# Altium schematic sheet MCU.SchDoc
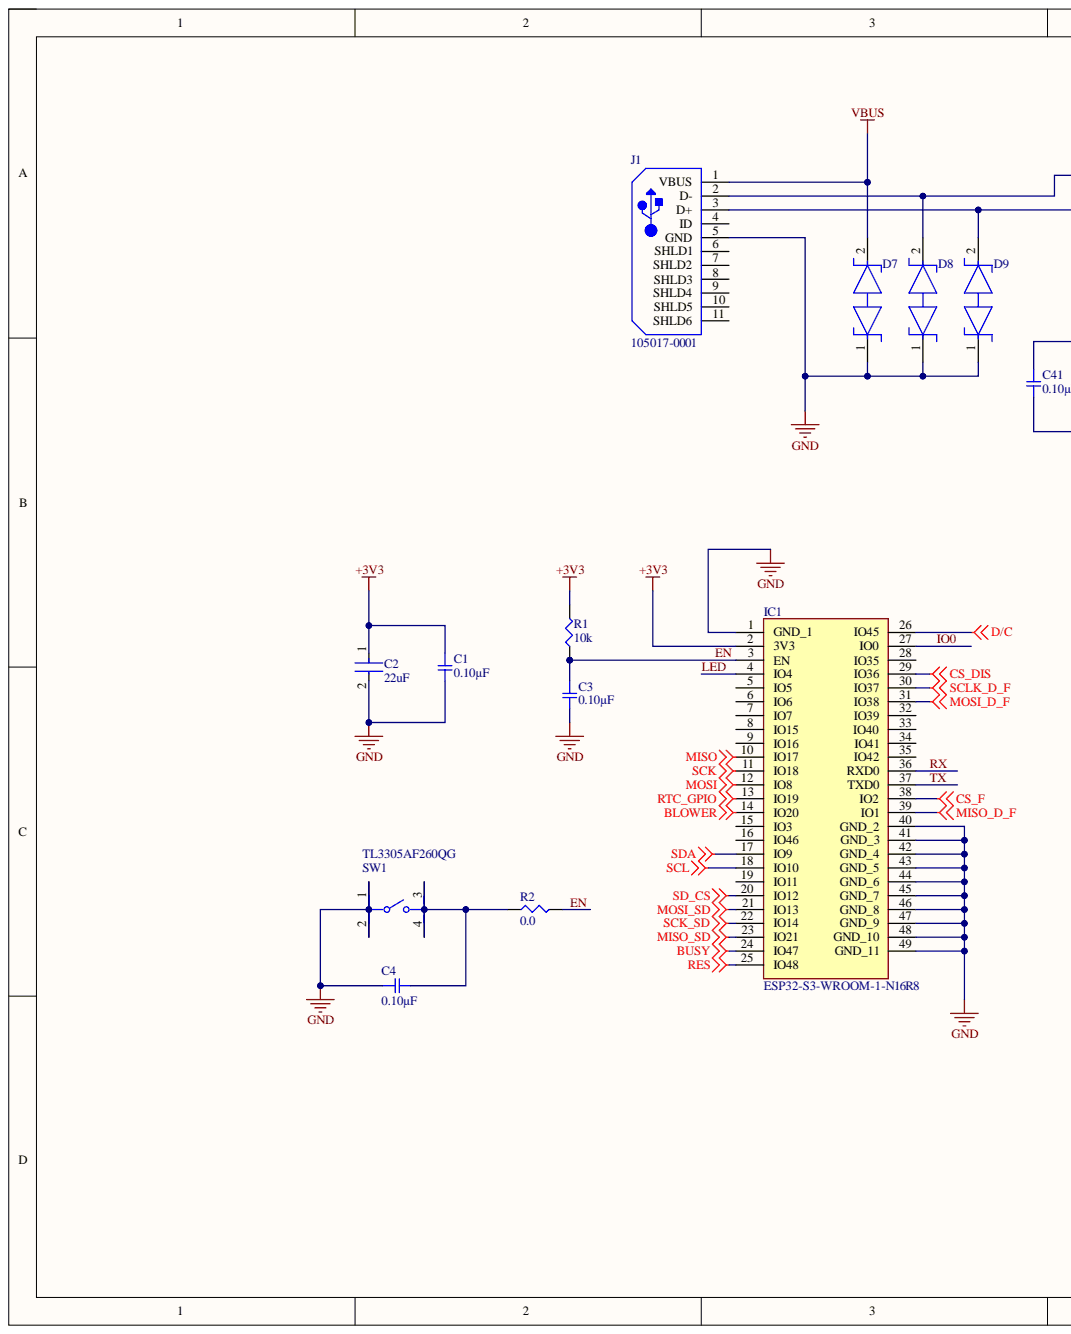
[diagram p0: MCU.SchDoc, left]
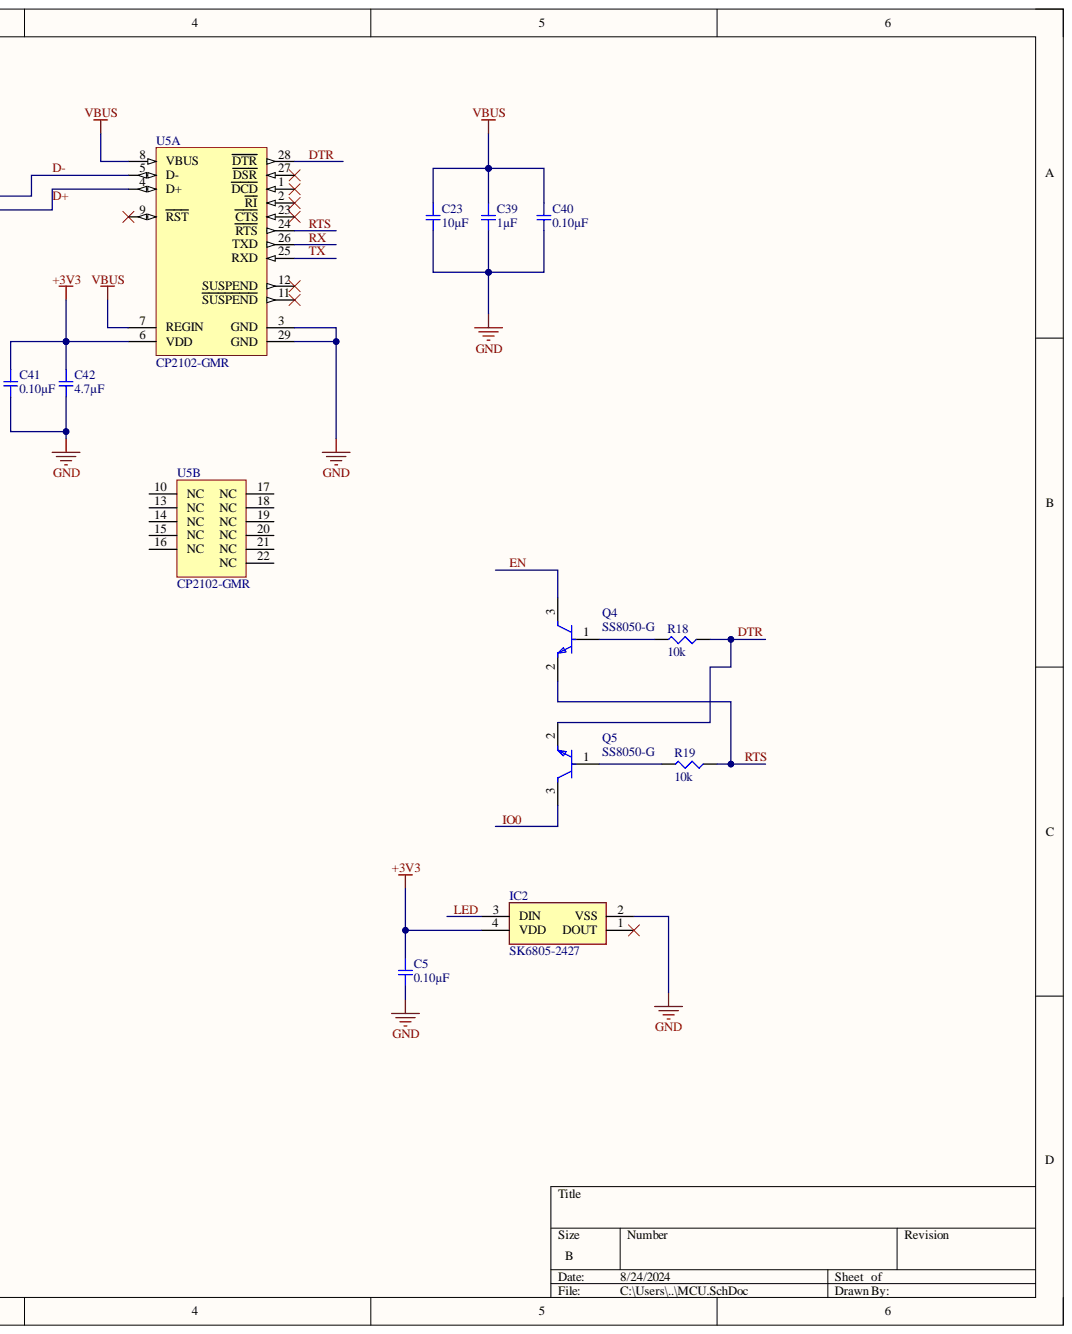
[diagram p1: MCU.SchDoc, right]

## Parts
C1  0.10µF  [SMD-0402C]
C2  22uF  [FP-CL05A226MQ5N6J8-IPC_C]
C3  0.10µF  [SMD-0402C]
C4  0.10µF  [SMD-0402C]
C5  0.10µF  [SMD-0402C]
C23  10µF  [SMD-0402C]
C39  1µF  [SMD-0402C]
C40  0.10µF  [SMD-0402C]
C41  0.10µF  [SMD-0402C]
C42  4.7µF  [SMD-0402C]
D7  PD1E10B06DPYR  [FP-DPY0002A-MFG]  (value not drawn)
D8  PD1E10B06DPYR  [FP-DPY0002A-MFG]  (value not drawn)
D9  PD1E10B06DPYR  [FP-DPY0002A-MFG]  (value not drawn)
IC1  ESP32-S3-WROOM-1-N16R8  [ESP32S3WROOM1N16R8]
IC2  SK6805-2427  [SK68052427]
J1  105017-0001  [USB-MICRO-B_V]
Q4  SS8050-G  [FP-SS8050-G-MFG]
Q5  SS8050-G  [FP-SS8050-G-MFG]
R1  10k  [SMD-0402-RES]
R2  0.0  [SMD-0402-RES]
R18  10k  [SMD-0402-RES]
R19  10k  [SMD-0402-RES]
SW1  TL3305AF260QG  [FP-TL3305AF260QG-MFG]
U5  CP2102-GMR  [SLAB-QFN-28-3125x3125TP_M]  (parts A,B)

## Nets
+3V3: C1.1 C2.1 C5.1 C41.1 C42.1 IC1.2(3V3) IC2.4(VDD) R1.2 U5.6(VDD)
BLOWER: IC1.14(IO20)
BUSY: IC1.24(IO47)
CS_DIS: IC1.29(IO36)
CS_F: IC1.38(IO2)
D/C: IC1.26(IO45)
GND: C1.2 C2.2 C3.2 C4.1 C5.2 C23.2 C39.2 C40.2 C41.2 C42.2 D7.1 D8.1 D9.1 IC1.1(GND_1) IC1.40(GND_2) IC1.41(GND_3) IC1.42(GND_4) IC1.43(GND_5) IC1.44(GND_6) IC1.45(GND_7) IC1.46(GND_8) IC1.47(GND_9) IC1.48(GND_10) IC1.49(GND_11) IC2.2(VSS) J1.5(GND) SW1.1 SW1.2 U5.3(GND) U5.29(GND)
MISO: IC1.10(IO17)
MISO_D_F: IC1.39(IO1)
MISO_SD: IC1.23(IO21)
MOSI: IC1.12(IO8)
MOSI_D_F: IC1.31(IO38)
MOSI_SD: IC1.21(IO13)
RES: IC1.25(IO48)
RTC_GPIO: IC1.13(IO19)
SCK: IC1.11(IO18)
SCK_SD: IC1.22(IO14)
SCL: IC1.18(IO10)
SCLK_D_F: IC1.30(IO37)
SD_CS: IC1.20(IO12)
SDA: IC1.17(IO9)
VBUS: C23.1 C39.1 C40.1 D7.2 J1.1(VBUS) U5.7(REGIN) U5.8(VBUS)
D+: D9.2 J1.3(D+) U5.4(D+)
D-: D8.2 J1.2(D-) U5.5(D-)
DTR: Q5.2 R18.1 U5.28(DTR)
EN: C3.1 IC1.3(EN) Q4.3 R1.1 R2.2
IO0: IC1.27(IO0) Q5.3
LED: IC1.4(IO4) IC2.3(DIN)
RTS: Q4.2 R19.1 U5.24(RTS)
RX: IC1.36(RXD0) U5.26(TXD)
TX: IC1.37(TXD0) U5.25(RXD)
NetC4_2: C4.2 R2.1 SW1.3 SW1.4
NetQ4_1: Q4.1 R18.2
NetQ5_1: Q5.1 R19.2

Not connected: IC1 5(IO5) 6(IO6) 7(IO7) 8(IO15) 9(IO16) 15(IO3) 16(IO46) 19(IO11) 28(IO35) 32(IO39) 33(IO40) 34(IO41) 35(IO42); IC2 1(DOUT); J1 4(ID) 6(SHLD1) 7(SHLD2) 8(SHLD3) 9(SHLD4) 10(SHLD5) 11(SHLD6); U5 1(DCD) 2(RI) 9(RST) 10(NC) 11(SUSPEND) 12(SUSPEND) 13(NC) 14(NC) 15(NC) 16(NC) 17(NC) 18(NC) 19(NC) 20(NC) 21(NC) 22(NC) 23(CTS) 27(DSR)

## Library data of the parts
C1 C3-C5 C40 C41 = GCM155R71C104KA55D: CAP CER 0.1UF 16V X7R 0402; Manufacturer: Murata Electronics North America; @ qty: 0; Minimum Quantity: 1; Tolerance: ±10%; Unit Price (USD): 0.1; Applications: Automotive; Component Kind: Standard; Datasheets: http://www.murata.com/~/media/webrenewal/support/library/catalog/products/capacitor/mlcc/c03e.ashx; Digi-Key Part Number: 490-4759-1-ND; Factory Stock: 0; Features: -; Height - Seated (Max): -; Image: //media.digikey.com/Renders/Murata%20Renders/ZRB-SERIES-0402.jpg; Lead Spacing: -; Lead Style: -; Library Name: capacitor_murata_0402.IntLib; Mounting Type: Surface Mount, MLCC; Operating Temperature: -55°C ~ 125°C; Package / Case: 0402 (1005 Metric); Packaging: Cut Tape (CT); Part Number: GCM155R71C104KA55D; Part Status: Active; Quantity Available: 734194; Ratings: AEC-Q200; Series: GCM; Size / Dimension: 0.039" L x 0.020" W (1.00mm x 0.50mm); Temperature Coefficient: X7R; Thickness (Max): 0.022" (0.55mm); Value: 0.10µF; Voltage: 16V
C2 = CMP-13271-000001-1: CAP CER 22UF 6.3V X5R 0402; Manufacturer: Samsung Electro-Mechanics; Manufacturer Part Number: CL05A226MQ5N6J8; Material: Ceramic; Temperature Coefficient: 15%; Tolerance: 20%; Automotive: No; Capacitance: 22uF; Category: Cap; Component Kind: Standard; ComponentLink2Description: Datasheet; ComponentLink2URL: http://www.samsungsem.com/kr/support/library/product-catalog/__icsFiles/afieldfile/2018/11/28/MLCC.pdf; Device Class L1: Passive Components; Device Class L2: Capacitors; Device Class L3: Ceramic Capacitors; Digikey Description: CAP CER 22UF 6.3V X5R 0402; Height: 0.55mm; Ipc Land Pattern Name: CAPC10050X50; Lead Free: Yes; Library Name: Altium Content Vault; Octopart: https://octopart.com/cl05a226mq5n6j8-samsung-82337113; Package: 0402; RoHS: TRUE; Temperature: -55°C to +85°C; Temperature Characteristic: X5R; Temperature Range High: +85°C; Temperature Range Low: -55°C; Value: 22uF; Voltage Rating: 6.3V
C23 = ZRB15XR60J106ME12D: CAP CER 10UF 6.3V X5R 0402; Manufacturer: Murata Electronics North America; @ qty: 0; Minimum Quantity: 1; Tolerance: ±20%; Unit Price (USD): 0.25; Applications: Acoustic Noise Reduction; Component Kind: Standard; Datasheets: http://psearch.en.murata.com/capacitor/product/ZRB15XR60J106ME12%23.pdf; Digi-Key Part Number: 490-13251-1-ND; Factory Stock: 0; Features: Derating Recommended, Interposer Termination; Height - Seated (Max): -; Image: //media.digikey.com/Renders/Murata%20Renders/ZRB-SERIES-0402.jpg; Lead Spacing: -; Lead Style: -; Library Name: capacitor_murata_0402.IntLib; Mounting Type: Surface Mount, MLCC; Operating Temperature: -55°C ~ 85°C; Package / Case: 0402 (1005 Metric); Packaging: Cut Tape (CT); Part Number: ZRB15XR60J106ME12D; Part Status: Active; Quantity Available: 8000; Ratings: -; Series: ZRB; Size / Dimension: 0.039" L x 0.020" W (1.00mm x 0.50mm); Temperature Coefficient: X5R; Thickness (Max): 0.031" (0.80mm); Value: 10µF; Voltage: 6.3V
C39 = GCM155C71A105KE38D: CAP CER 1UF 10V X7S 0402; Manufacturer: Murata Electronics North America; @ qty: 0; Minimum Quantity: 1; Tolerance: ±10%; Unit Price (USD): 0.12; Applications: Automotive; Component Kind: Standard; Datasheets: http://www.murata.com/~/media/webrenewal/support/library/catalog/products/capacitor/mlcc/c03e.ashx; Digi-Key Part Number: 490-13409-1-ND; Factory Stock: 0; Features: -; Height - Seated (Max): -; Image: //media.digikey.com/Renders/Murata%20Renders/ZRB-SERIES-0402.jpg; Lead Spacing: -; Lead Style: -; Library Name: capacitor_murata_0402.IntLib; Mounting Type: Surface Mount, MLCC; Operating Temperature: -55°C ~ 125°C; Package / Case: 0402 (1005 Metric); Packaging: Cut Tape (CT); Part Number: GCM155C71A105KE38D; Part Status: Active; Quantity Available: 7240; Ratings: AEC-Q200; Series: GCM; Size / Dimension: 0.039" L x 0.020" W (1.00mm x 0.50mm); Temperature Coefficient: X7S; Thickness (Max): 0.022" (0.55mm); Value: 1µF; Voltage: 10V
C42 = ZRB15XR61A475KE01D: CAP CER 4.7UF 10V X5R 0402; Manufacturer: Murata Electronics North America; @ qty: 0; Minimum Quantity: 1; Tolerance: ±10%; Unit Price (USD): 0.28; Applications: Acoustic Noise Reduction; Component Kind: Standard; Datasheets: http://search.murata.co.jp/Ceramy/image/img/A01X/G101/ENG/ZRB15XR61A475KE01-01.pdf; Digi-Key Part Number: 490-13252-1-ND; Factory Stock: 0; Features: Derating Recommended, Interposer Termination; Height - Seated (Max): -; Image: //media.digikey.com/Renders/Murata%20Renders/ZRB-SERIES-0402.jpg; Lead Spacing: -; Lead Style: -; Library Name: capacitor_murata_0402.IntLib; Mounting Type: Surface Mount, MLCC; Operating Temperature: -55°C ~ 85°C; Package / Case: 0402 (1005 Metric); Packaging: Cut Tape (CT); Part Number: ZRB15XR61A475KE01D; Part Status: Active; Quantity Available: 23076; Ratings: -; Series: ZRB; Size / Dimension: 0.039" L x 0.020" W (1.00mm x 0.50mm); Temperature Coefficient: X5R; Thickness (Max): 0.031" (0.80mm); Value: 4.7µF; Voltage: 10V
D7-D9 = CMP-0326-00004-4: TVS DIODE 5.5V 14V 2X1SON; Breakdown Voltage: 6V; Capacitance: 12pF; Direction: Bidirectional; Element Configuration: Single; ESD Protection: Yes; Interface: GPIO; Leakage Current: 100nA; Number of Bidirectional Channels: 1; Peak Pulse Current: 5A; Peak Pulse Power: 90W; Power Line Protection: No; Test Current: 1mA; Case/Package: 0402; Clamping Voltage: 14V; Component Kind: Standard; Composition: Zener; Contact Plating: Gold; Height: 0.35mm; Lead Free: Lead Free; Length: 1.1mm; Library Name: Altium Content Vault; Max Operating Temperature: 125°C; Max Reverse Leakage Current: 100nA; Min Breakdown Voltage: 6V; Min Operating Temperature: -40°C; Number of Channels: 1; Number of Pins: 2; Package Quantity: 1; Packaging: Tape and Reel; Radiation Hardening: No; REACH SVHC: No SVHC; Reverse Standoff Voltage: 5.5V; Width: 0.7mm; Working Voltage: 5.5V
IC1 = ESP32-S3-WROOM-1-N16R8: Integrated Circuit; Manufacturer_Name: Espressif Systems; Component Kind: Standard; Datasheet Link: https://www.espressif.com/sites/default/files/documentation/esp32-s3-wroom-1_wroom-1u_datasheet_en.pdf; Height: 3.25mm; Library Name: SamacSys.SchLib; Manufacturer_Part_Number: ESP32-S3-WROOM-1-N16R8; Mouser Part Number: 356-ESP32S3WRM1N16R8; Mouser Price/Stock: https://www.mouser.co.uk/ProductDetail/Espressif-Systems/ESP32-S3-WROOM-1-N16R8?qs=Li%252BoUPsLEnvQc9gW6AMhZg%3D%3D
IC2 = SK6805-2427: Integrated Circuit; Manufacturer_Name: Adafruit; Component Kind: Standard; Datasheet Link: https://cdn-shop.adafruit.com/product-files/3484/3484_Datasheet.pdf; Height: 1.1mm; Manufacturer_Part_Number: SK6805-2427
J1 = CMP-2000-05827-1: Micro-USB B Receptacle, Right Angle, Bottom Mount, Surface Mount, with Solder Tabs, -30 to 85 degC, 5-Pin USB, RoHS, Tape and Reel; Manufacturer: Molex; 3D Model: 105017-0001.stp; Catalog Drawings: 1050170001; Circuits: 5; Connector Type: USB-microB; Featured Product: Micro-USBConnectorsUSBConnectors; Mating Products: WM17145-ND-CABLEMICROUSBBTOSTDA1.0MWM17147-ND-CABLEMICROUSBBTOSTDA2.0MWM17146-ND-CABLEMICROUSBBTOSTDA1.5M; Online Catalog: Molex-MicroUSB; Other Names: WM1399DKR; Package Version: Rev. F, 12/2012; PCN Assembly/Origin: ResinSupplier21/Oct/2015; Voltage - Max: 30V; Category: Connectors,Interconnects; Component Kind: Standard; Datasheet URL: http://www.molex.com/elqNow/elqRedir.htm?ref=http://www.molex.com/webdocs/datasheets/pdf/en-us/1050170001_IO_CONNECTORS.pdf; Datasheet Version: 03/2016; Family: USB,DVI,HDMIConnectors; Features: Shielded,SolderRetention; Gender: Receptacle; Ingress Protection: -; Library Name: Altium Content Vault; Manufacturer URL: http://www.molex.com/; Mounting Technology: SurfaceMount; Mounting Type: BoardCutout,SurfaceMount,RightAngle; Number of Contacts: 5; Package Description: 5-Leads USB, Micro B, Body 7.5 x 5 mm, Pitch 0.65 mm; Package Reference: MICRO-B; Package URL: http://www.molex.com/pdm_docs/sd/1050170001_sd.pdf; Packaging: Digi-Reel®; RoHS Information: 1050170001CertofCompliance; Series: -; Specifications: -; Standard Package: 1
Q4 Q5 = CMP-20235-000001-1: NPN TRANSISTOR 1.5A 40V SOT-23 R; Collector Emitter Breakdown Voltage: 25V; Collector Emitter Voltage (VCEO): 400mV; Max Power Dissipation: 300mW; Transition Frequency: 100MHz; Case/Package: TO-236-3; Component Kind: Standard; Library Name: Altium Content Vault; Max Breakdown Voltage: 25V; Max Collector Current: 1.5A; Mount: Surface Mount; Packaging: Tape and Reel; RoHS Compliant: Yes
R1 R18 R19 = ERJ-2GEJ103X: RES SMD 10K OHM 5% 1/10W 0402; Manufacturer: Panasonic Electronic Components; @ qty: 1500; Factory Stock: 0; Minimum Quantity: 1; Power (Watts): 0.1W, 1/10W; Temperature Coefficient: ±200ppm/°C; Unit Price (USD): 0.00350; Component Kind: Standard; Composition: Thick Film; Datasheets: http://industrial.panasonic.com/www-cgi/jvcr13pz.cgi?E+PZ+3+AOA0001+ERJ2GEJ103X+7+WW; Digi-Key Part Number: P10KJCT-ND; Failure Rate: -; Features: Automotive AEC-Q200; Height - Seated (Max): 0.016" (0.40mm); Image: //media.digikey.com/Photos/Panasonic%20Photos/ERJ-PA2-Series.jpg; Library Name: Panasonic_ERJ_0402.IntLib; Number of Terminations: 2; Operating Temperature: -55°C ~ 155°C; Package / Case: 0402 (1005 Metric); Packaging: Cut Tape (CT); Part Number: ERJ-2GEJ103X; Part Status: Active; Quantity Available: 2560215; Series: ERJ; Size / Dimension: 0.039" L x 0.020" W (1.00mm x 0.50mm); Supplier Device Package: 0402; Tolerance: ±5%; Value: 10k
R2 = ERJ-2GE0R00X: RES SMD 0.0OHM JUMPER 1/10W 0402; Manufacturer: Panasonic Electronic Components; @ qty: 1500; Factory Stock: 0; Minimum Quantity: 1; Power (Watts): 0.1W, 1/10W; Unit Price (USD): 0.00384; Component Kind: Standard; Composition: Thick Film; Datasheets: http://industrial.panasonic.com/www-cgi/jvcr13pz.cgi?E+PZ+3+AOA0001+ERJ2GE0R00X+7+WW; Digi-Key Part Number: P0.0JCT-ND; Failure Rate: -; Features: Automotive AEC-Q200; Height - Seated (Max): 0.016" (0.40mm); Image: //media.digikey.com/Photos/Panasonic%20Photos/ERJ-PA2-Series.jpg; Library Name: Panasonic_ERJ_0402.IntLib; Number of Terminations: 2; Operating Temperature: -55°C ~ 155°C; Package / Case: 0402 (1005 Metric); Packaging: Cut Tape (CT); Part Number: ERJ-2GE0R00X; Part Status: Active; Quantity Available: 3152483; Series: ERJ; Size / Dimension: 0.039" L x 0.020" W (1.00mm x 0.50mm); Supplier Device Package: 0402; Temperature Coefficient: -; Tolerance: Jumper; Value: 0.0
SW1 = CMP-17879-000010-1: SWITCH TACTILE SPST-NO 50MA 12V; Actuator Type: Standard; Illumination Type: Non-Illuminated; Mechanical Life: 200000Cycles; Operating Force: 260gf; Switch Function: Off-Mom; Switch Travel: 0.2mm; Throw Configuration: SPST; Component Kind: Standard; Lead Free: Lead Free; Library Name: Altium Content Vault; Max Operating Temperature: 70°C; Min Operating Temperature: -20°C; Mount: Surface Mount; Packaging: Tape and Reel; Termination: Gull Wing
U5 = CMP-2000-04924-1: Single-Chip USB to URAT Bridge, 3.3 V, -40 to 85 degC, 28-Pin QFN, RoHS, Tape and Reel; Manufacturer: Silicon Labs; Data Rate: 1000kbps; Interface Circuit Standard 1: RS-232; Number of Channels: 1; Operating Supply Voltage (Max): 3.6V; Operating Supply Voltage (Min): 3V; Operating Supply Voltage (Typ): 3.3V; Rad Hardened: No; Supply Current: 26mA; Component Kind: Standard; Datasheet URL: http://www.mouser.com/ds/2/368/CP2102-9-247052.pdf; Datasheet Version: Rev. 1.6, 12/2013; Library Name: Altium Content Vault; Manufacturer URL: http://www.silabs.com/; Mounting: SurfaceMount; Operating Temperature (Max): 85C; Operating Temperature (Min): -40C; Operating Temperature Classification: Industrial; Package Description: 28-Pin Quad-Flat No-Leads Package, Body 5 x 5 mm, Pitch 0.5 mm; Package Reference: QFN-28; Package Type: QFNEP; Package URL: http://www.silabs.com/Support%20Documents/TechnicalDocs/C8051T61x.pdf; Packaging: TapeandReel; Pin Count: 28; Transmitter and Receiver FIFO Counter: No
